# Altium schematic sheet Sch12 - Analog Inputs 2.SchDoc("Sch12 - Analog Inputs 2")
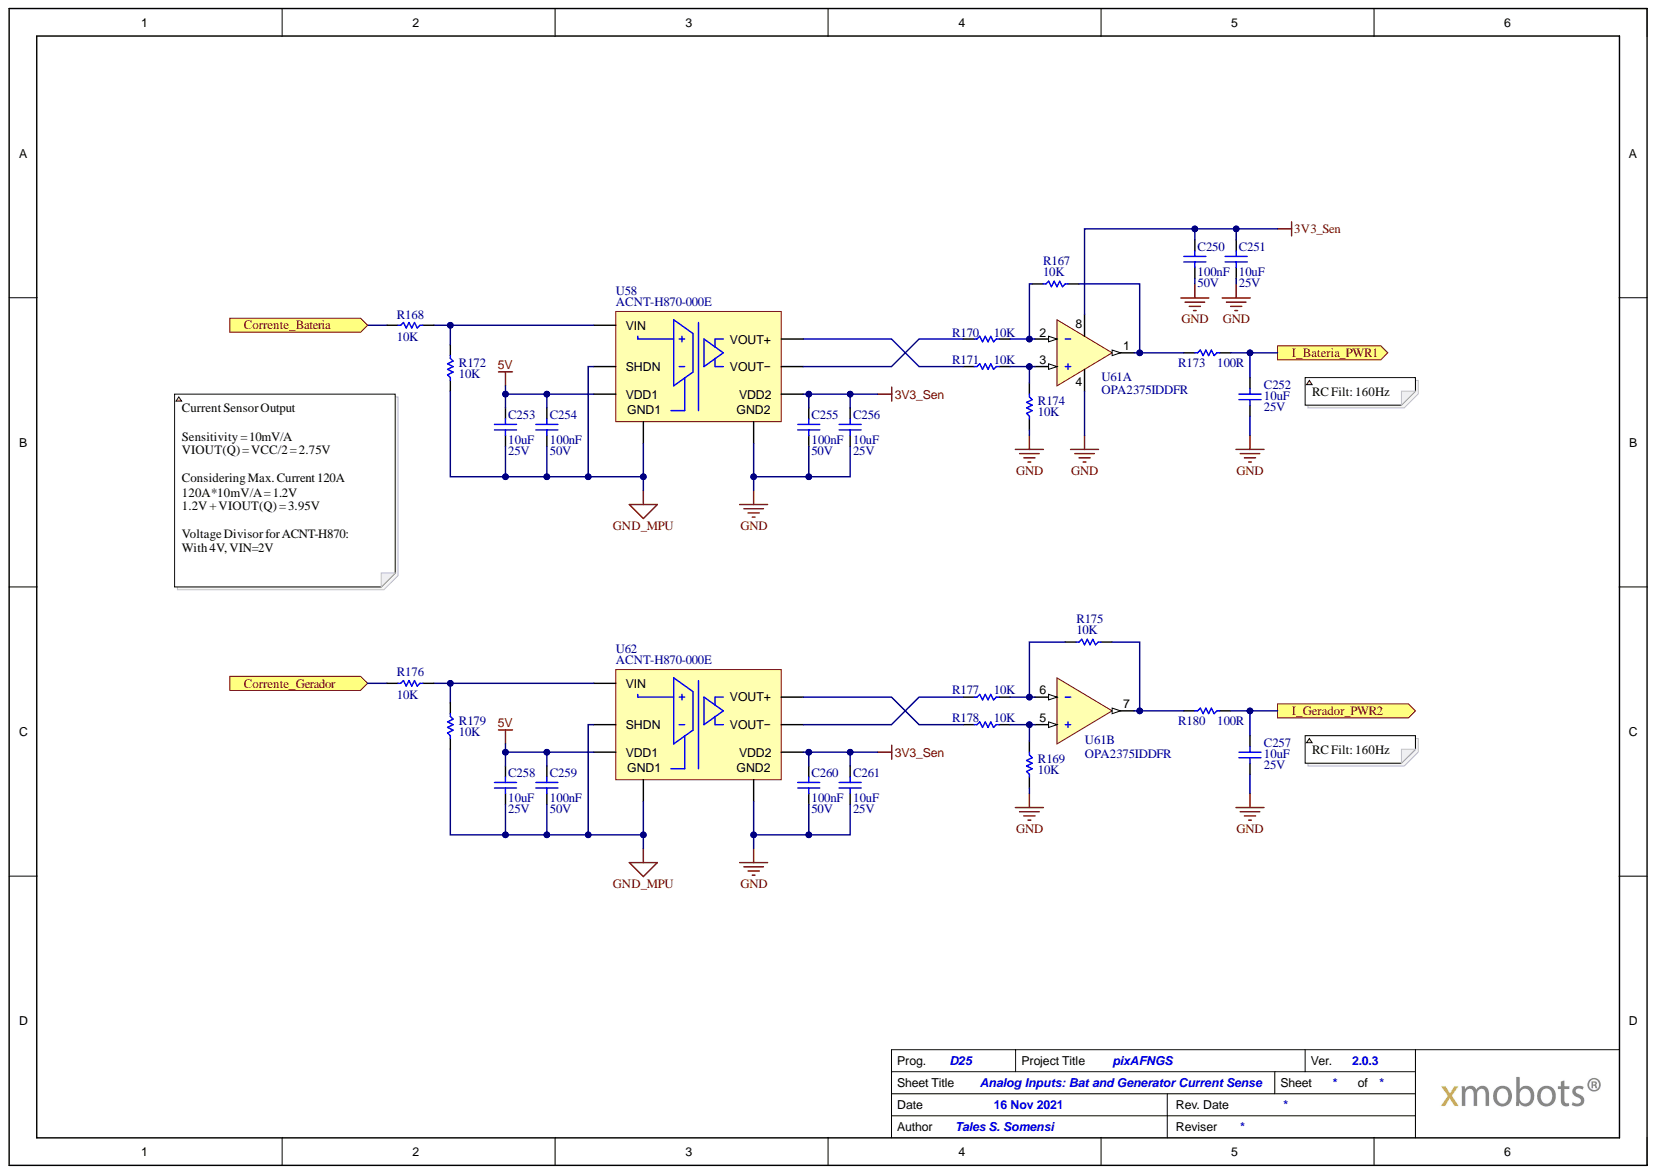
[diagram p0: Sch12 - Analog Inputs 2.SchDoc]

## Parts
C250  Capacitance: 100nF  Voltage: 50V  [0603]  (hidden comment: CL10B104MB8NNNC)
C251  Capacitance: 10uF  Voltage: 25V  [0603]  (hidden comment: GRM188R61E106KA73J)
C252  Capacitance: 10uF  Voltage: 25V  [0603]  (hidden comment: GRM188R61E106KA73J)
C253  Capacitance: 10uF  Voltage: 25V  [0603]  (hidden comment: GRM188R61E106KA73J)
C254  Capacitance: 100nF  Voltage: 50V  [0603]  (hidden comment: CL10B104MB8NNNC)
C255  Capacitance: 100nF  Voltage: 50V  [0603]  (hidden comment: CL10B104MB8NNNC)
C256  Capacitance: 10uF  Voltage: 25V  [0603]  (hidden comment: GRM188R61E106KA73J)
C257  Capacitance: 10uF  Voltage: 25V  [0603]  (hidden comment: GRM188R61E106KA73J)
C258  Capacitance: 10uF  Voltage: 25V  [0603]  (hidden comment: GRM188R61E106KA73J)
C259  Capacitance: 100nF  Voltage: 50V  [0603]  (hidden comment: CL10B104MB8NNNC)
C260  Capacitance: 100nF  Voltage: 50V  [0603]  (hidden comment: CL10B104MB8NNNC)
C261  Capacitance: 10uF  Voltage: 25V  [0603]  (hidden comment: GRM188R61E106KA73J)
R167  Resistance: 10K  [Res_0603]  (hidden comment: RMCF0603FT10K0)
R168  Resistance: 10K  [Res_0603]  (hidden comment: RMCF0603FT10K0)
R169  Resistance: 10K  [Res_0603]  (hidden comment: RMCF0603FT10K0)
R170  Resistance: 10K  [Res_0603]  (hidden comment: RMCF0603FT10K0)
R171  Resistance: 10K  [Res_0603]  (hidden comment: RMCF0603FT10K0)
R172  Resistance: 10K  [Res_0603]  (hidden comment: RMCF0603FT10K0)
R173  Resistance: 100R  [Res_0603]  (hidden comment: RMCF0603FT100R)
R174  Resistance: 10K  [Res_0603]  (hidden comment: RMCF0603FT10K0)
R175  Resistance: 10K  [Res_0603]  (hidden comment: RMCF0603FT10K0)
R176  Resistance: 10K  [Res_0603]  (hidden comment: RMCF0603FT10K0)
R177  Resistance: 10K  [Res_0603]  (hidden comment: RMCF0603FT10K0)
R178  Resistance: 10K  [Res_0603]  (hidden comment: RMCF0603FT10K0)
R179  Resistance: 10K  [Res_0603]  (hidden comment: RMCF0603FT10K0)
R180  Resistance: 100R  [Res_0603]  (hidden comment: RMCF0603FT100R)
U58  ACNT-H870-000E  [stretched_SO-8]
U61  OPA2375IDDFR  [SOT-23-8]  (parts A,B)
U62  ACNT-H870-000E  [stretched_SO-8]

## Ports
Corrente_Bateria, Corrente_Gerador, I_Bateria_PWR1, I_Gerador_PWR2

## Nets
3V3_Sen: C250.2 C251.2 C255.2 C256.2 C260.2 C261.2 U58.8(VDD2) U61.8 U62.8(VDD2)
5V: C253.2 C254.2 C258.2 C259.2 U58.1(VDD1) U62.1(VDD1)
GND: C250.1 C251.1 C252.1 C255.1 C256.1 C257.1 C260.1 C261.1 R169.1 R174.1 U58.5 U61.4 U62.5
GND_MPU: C253.1 C254.1 C258.1 C259.1 R172.1 R179.1 U58.3(SHDN) U58.4 U62.3(SHDN) U62.4
Corrente_Bateria: R168.2 ; port Corrente_Bateria
Corrente_Gerador: R176.2 ; port Corrente_Gerador
I_Bateria_PWR1: C252.2 R173.2 ; port I_Bateria_PWR1
I_Gerador_PWR2: C257.2 R180.2 ; port I_Gerador_PWR2
NetR167_1: R167.1 R173.1 U61.1
NetR167_2: R167.2 R170.1 U61.2
NetR168_1: R168.1 R172.2 U58.2(VIN)
NetR169_2: R169.2 R178.1 U61.5
NetR170_2: R170.2 U58.6(VOUT−)
NetR171_1: R171.1 R174.2 U61.3
NetR171_2: R171.2 U58.7(VOUT+)
NetR175_1: R175.1 R180.1 U61.7
NetR175_2: R175.2 R177.1 U61.6
NetR176_1: R176.1 R179.2 U62.2(VIN)
NetR177_2: R177.2 U62.6(VOUT−)
NetR178_2: R178.2 U62.7(VOUT+)

## Library data of the parts
C250 C254 C255 C259 C260 = C-100nF-50V-X7R-0603: Capacitor 100nF 50V X7R 0603; Component Kind: Standard; Part Number: CL10B104MB8NNNC; Library Name: xmobots_-_comp_library.IntLib
C251-C253 C256-C258 C261 = C-CER-10uF-25V-X5R-0603: Capacitor 10uF 25V X5R 0603; Component Kind: Standard; Part Number: GRM188R61E106KA73J; Library Name: xmobots_-_comp_library.IntLib
R167-R172 R174-R179 = R-10K-1/10W-1%-0603: Resistor 10k ohms 1/10W 1% 0603; Component Kind: Standard; Part Number: RMCF0603FT10K0; Power: 1/10W; Tolerance: ±1%; Library Name: xmobots_-_comp_library.IntLib
R173 R180 = R-100R-1/10W-1%-0603: Resistor 100 ohms 1/10W 1% 0603; Component Kind: Standard; Part Number: RMCF0603FT100R; Power: 1/10W; Tolerance: ±1%; Library Name: xmobots_-_comp_library.IntLib
U61 = U-OP_AMP-OPA2375IDDFR: Operational Amplifier, 10-MHz, Low Broadband Noise, RRO; Component Kind: Standard; Library Name: xmobots_-_comp_library.IntLib
U58 U62 = ACNT-H870-000E: Component Kind: Standard; Library Name: WarLib.IntLib; Part Number: ACNT-H870-000E
